# Altium schematic sheet BME280.SchDoc(BME280)
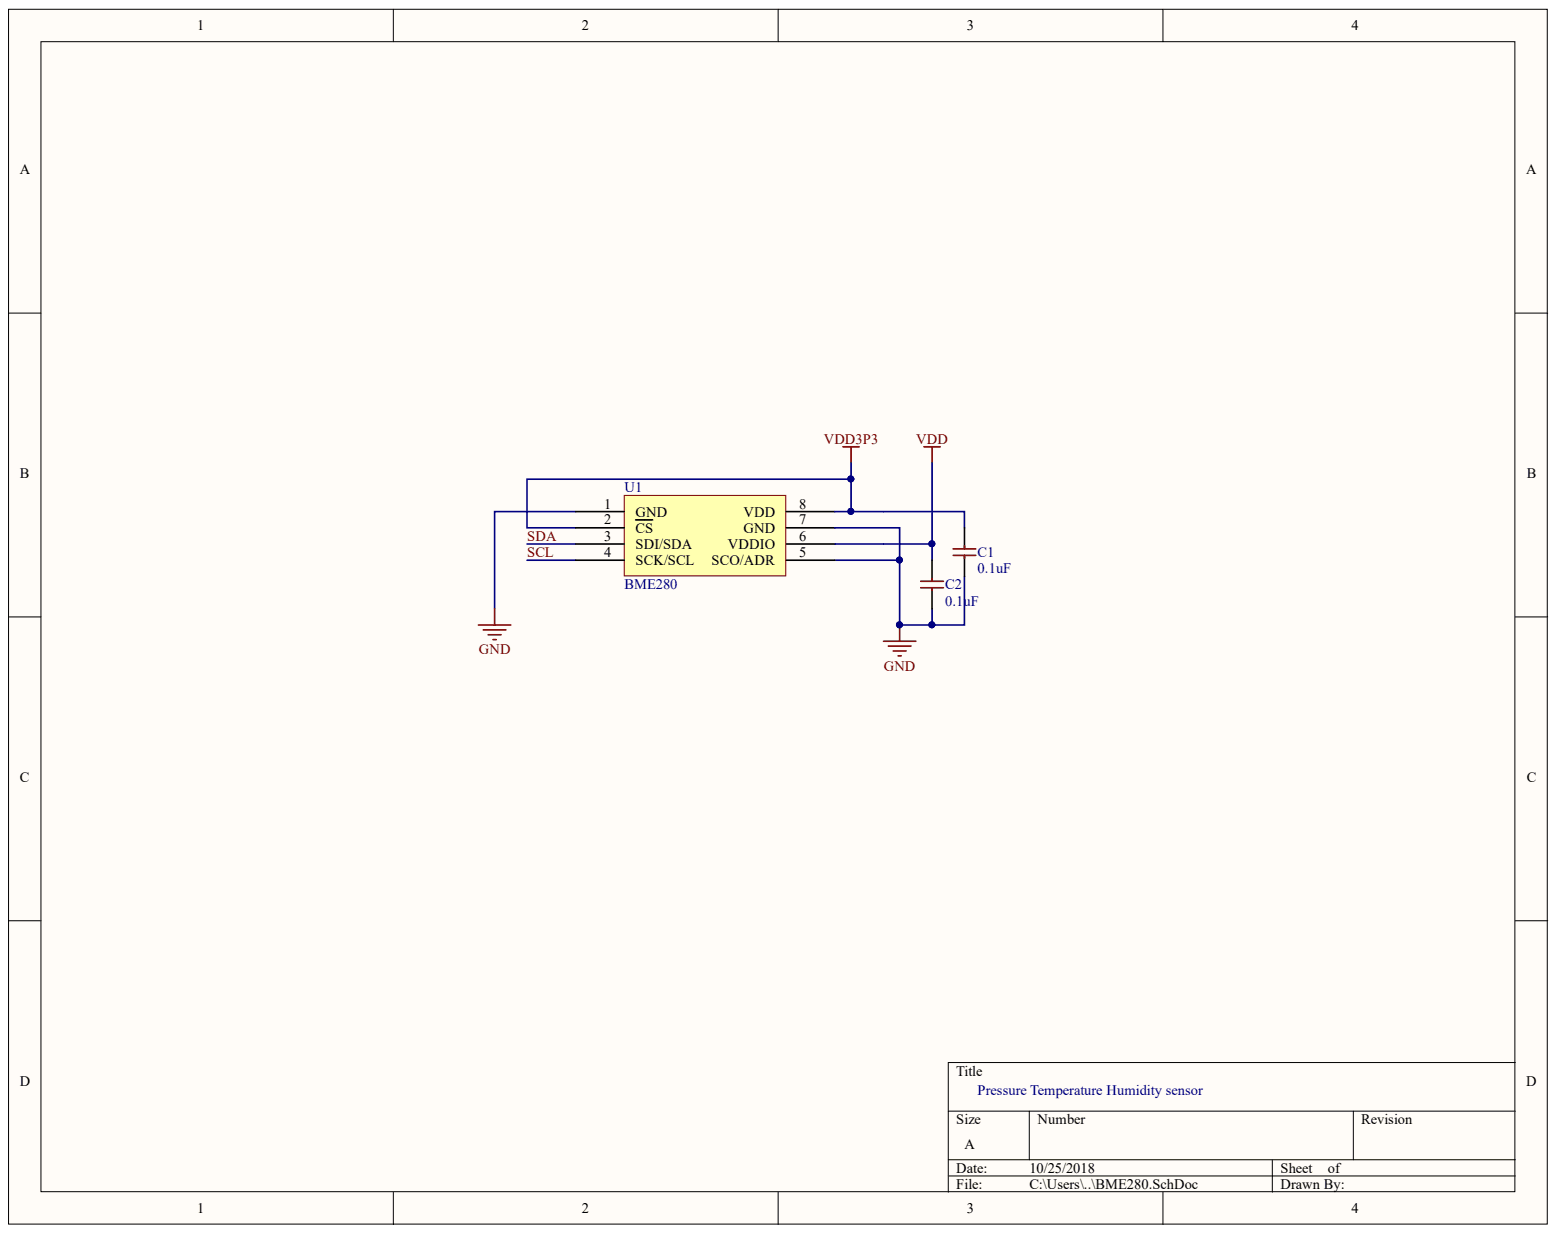
[diagram p0: BME280.SchDoc]

## Parts
C1  0.1uF  [CAP0603]
C2  0.1uF  [CAP0603]
U1  BME280  [LGA]

## Nets
GND: C1.1 C2.1 U1.1(GND) U1.5(SCO/ADR) U1.7(0x76)
VDD: C2.2 U1.6(VDDIO)
VDD3P3: C1.2 U1.2(CS) U1.8(VDD)
SCL: U1.4(SCK/SCL)
SDA: U1.3(SDI/SDA)

## Library data of the parts
C1 C2 = Capacitor: Component Kind: Standard; Library Name: karo_components.IntLib
U1 = BME280: Component Kind: Standard; Library Name: karo_components.IntLib
